# Altium schematic sheet main.SchDoc
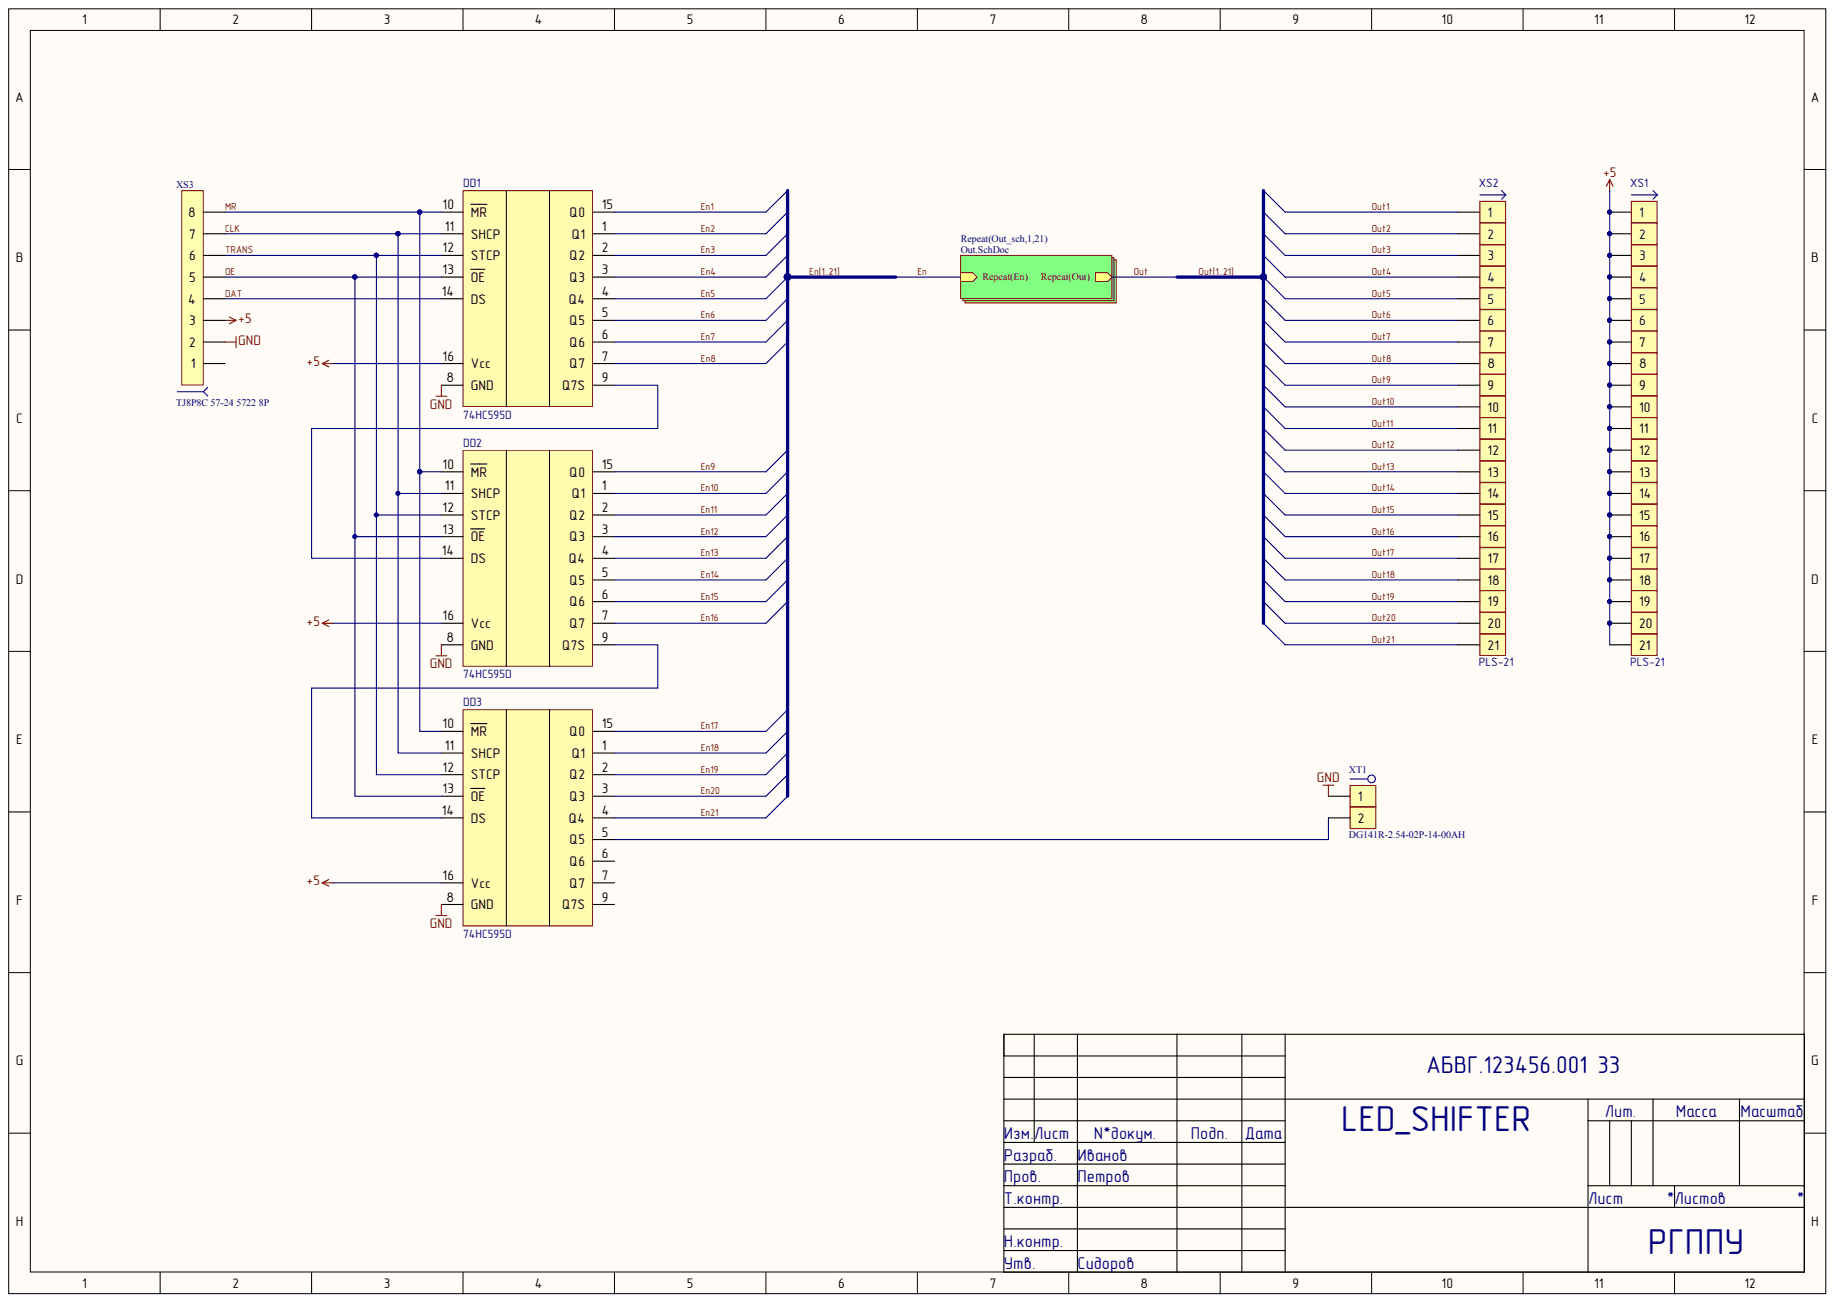
[diagram p0: main.SchDoc]

## Parts
DD1  74HC595D
DD2  74HC595D
DD3  74HC595D
XS1  PLS-21
XS2  PLS-21
XS3  TJ8P8C 57-24 5722 8P  (value not drawn)
XT1  DG141R-2.54-02P-14-00AH

## Nets
+5: DD1.16(Vcc) DD2.16(Vcc) DD3.16(Vcc) XS1.1 XS1.2 XS1.3 XS1.4 XS1.5 XS1.6 XS1.7 XS1.8 XS1.9 XS1.10 XS1.11 XS1.12 XS1.13 XS1.14 XS1.15 XS1.16 XS1.17 XS1.18 XS1.19 XS1.20 XS1.21 XS3.3
GND: DD1.8(GND) DD2.8(GND) DD3.8(GND) XS3.2 XT1.1
CLK: DD1.11(SHCP) DD2.11(SHCP) DD3.11(SHCP) XS3.7
DAT: DD1.14(DS) XS3.4
EN1: DD1.15(Q0)  [also labelled EN]
EN2: DD1.1(Q1)  [also labelled EN]
EN3: DD1.2(Q2)  [also labelled EN]
EN4: DD1.3(Q3)  [also labelled EN]
EN5: DD1.4(Q4)  [also labelled EN]
EN6: DD1.5(Q5)  [also labelled EN]
EN7: DD1.6(Q6)  [also labelled EN]
EN8: DD1.7(Q7)  [also labelled EN]
EN9: DD2.15(Q0)  [also labelled EN]
EN10: DD2.1(Q1)  [also labelled EN]
EN11: DD2.2(Q2)  [also labelled EN]
EN12: DD2.3(Q3)  [also labelled EN]
EN13: DD2.4(Q4)  [also labelled EN]
EN14: DD2.5(Q5)  [also labelled EN]
EN15: DD2.6(Q6)  [also labelled EN]
EN16: DD2.7(Q7)  [also labelled EN]
EN17: DD3.15(Q0)  [also labelled EN]
EN18: DD3.1(Q1)  [also labelled EN]
EN19: DD3.2(Q2)  [also labelled EN]
EN20: DD3.3(Q3)  [also labelled EN]
EN21: DD3.4(Q4)  [also labelled EN]
MR: DD1.10(MR) DD2.10(MR) DD3.10(MR) XS3.8
OE: DD1.13(OE) DD2.13(OE) DD3.13(OE) XS3.5
OUT1: XS2.1  [also labelled OUT]
OUT2: XS2.2  [also labelled OUT]
OUT3: XS2.3  [also labelled OUT]
OUT4: XS2.4  [also labelled OUT]
OUT5: XS2.5  [also labelled OUT]
OUT6: XS2.6  [also labelled OUT]
OUT7: XS2.7  [also labelled OUT]
OUT8: XS2.8  [also labelled OUT]
OUT9: XS2.9  [also labelled OUT]
OUT10: XS2.10  [also labelled OUT]
OUT11: XS2.11  [also labelled OUT]
OUT12: XS2.12  [also labelled OUT]
OUT13: XS2.13  [also labelled OUT]
OUT14: XS2.14  [also labelled OUT]
OUT15: XS2.15  [also labelled OUT]
OUT16: XS2.16  [also labelled OUT]
OUT17: XS2.17  [also labelled OUT]
OUT18: XS2.18  [also labelled OUT]
OUT19: XS2.19  [also labelled OUT]
OUT20: XS2.20  [also labelled OUT]
OUT21: XS2.21  [also labelled OUT]
TRANS: DD1.12(STCP) DD2.12(STCP) DD3.12(STCP) XS3.6
NetDD1_9: DD1.9(Q7S) DD2.14(DS)
NetDD2_9: DD2.9(Q7S) DD3.14(DS)
NetDD3_5: DD3.5(Q5) XT1.2
NetDD3_6: DD3.6(Q6)
NetDD3_7: DD3.7(Q7)
NetDD3_9: DD3.9(Q7S)
NetXS3_1: XS3.1

## Library data of the parts
DD1-DD3 = (no symbol name): Manufacturer: NXP; ManufacturerPartNumber: 74HC595D; ComponentLink1URL: http://www.tme.eu/en/details/74hc595d/shift-registers/; ComponentLink1Description: http://www.tme.eu/en/details/74hc595d/shift-registers/; Supplier: *; Price: 25; Note: *
XS1-XS3 XT1 = (no symbol name)
  XS1 XS2: ManufacturerPartNumber: PLS-21; ComponentLink1Description: Datasheet; ComponentLink1URL: http://www.promelec.ru/catalog/406/1218/1224/1/24233/; ComponentLink2Description: Datasheet-local; ComponentLink2URL: *; Price: 1
  XS3: ManufacturerPartNumber: TJ8P8C 57-24 5722 8P
  XT1: ManufacturerPartNumber: DG141R-2.54-02P-14-00AH
